# Altium schematic sheet channel-multiplexer.SchDoc
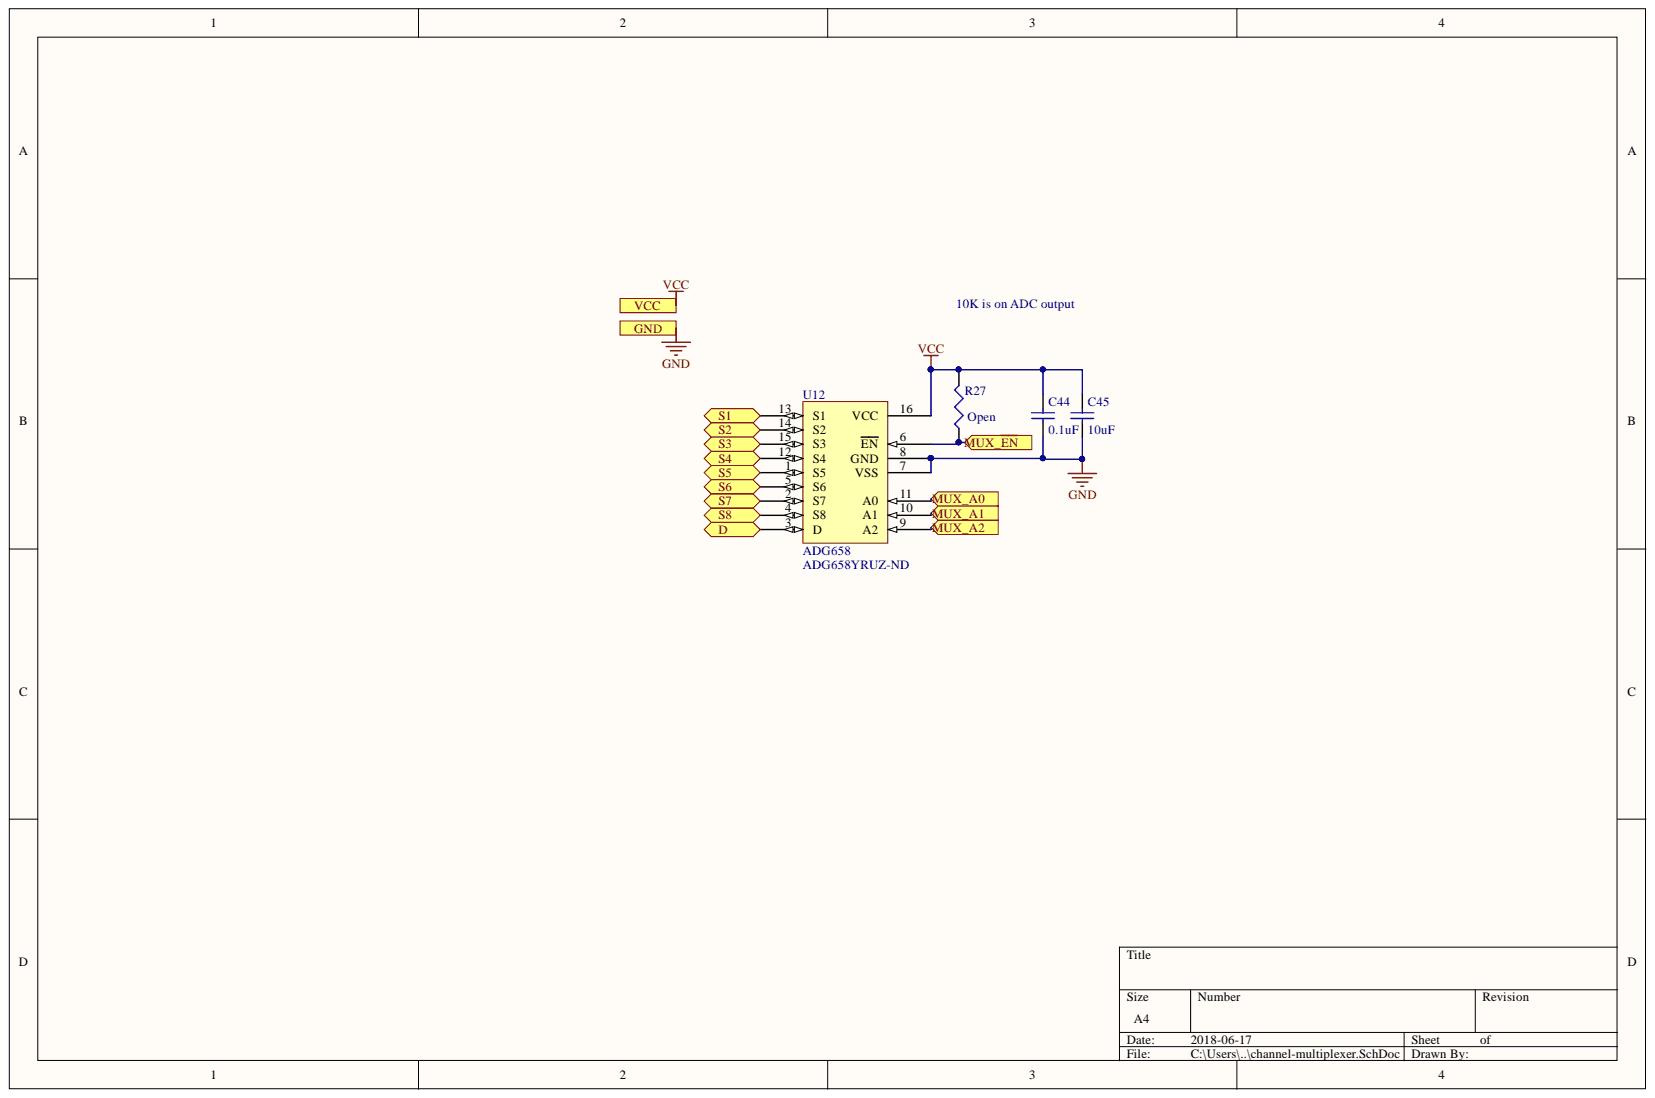
[diagram p0: channel-multiplexer.SchDoc]

## Parts
C44  0.1uF  [0402]
C45  10uF  [1608[0603]]
R27  Open  [0402]
U12  ADG658  Supplier Part Number 1: ADG658YRUZ-ND  [SSOP16_N]

## Ports
D, GND, MUX_A0, MUX_A1, MUX_A2, MUX_~{EN}, S1, S2, S3, S4, S5, S6, S7, S8, VCC

## Nets
D: U12.3(D) ; port D
GND: C44.1 C45.1 U12.7(VSS) U12.8(GND) ; port GND
MUX_A0: U12.11(A0) ; port MUX_A0
MUX_A1: U12.10(A1) ; port MUX_A1
MUX_A2: U12.9(A2) ; port MUX_A2
MUX_~{EN}: R27.1 U12.6(EN) ; port MUX_~{EN}
S1: U12.13(S1) ; port S1
S2: U12.14(S2) ; port S2
S3: U12.15(S3) ; port S3
S4: U12.12(S4) ; port S4
S5: U12.1(S5) ; port S5
S6: U12.5(S6) ; port S6
S7: U12.2(S7) ; port S7
S8: U12.4(S8) ; port S8
VCC: C44.2 C45.2 R27.2 U12.16(VCC) ; port VCC

## Library data of the parts
C44 C45 = Cap Semi: Capacitor (Semiconductor SIM Model); Component Kind: Standard; LatestRevisionDate: 29-May-2009; LatestRevisionNote: IPC-7351 Footprint Added.; Library Name: Miscellaneous Devices.IntLib; PackageDescription: Chip Capacitor; PackageReference: C1206; Published: 8-Jun-2000; Signal Integrity: Cap Semi; Simulation: CAP; Supplier: Digi-Key; Publisher: Altium Limited
  C44: Value: 0.1uF
  C45: Value: 10uF
R27 = Res3: Resistor; Component Kind: Standard; LatestRevisionDate: 29-May-2009; LatestRevisionNote: IPC-7351 Footprint Added.; Library Name: Miscellaneous Devices.IntLib; PackageDescription: Chip Resistor; PackageReference: J1-0603; Published: 8-Jun-2000; Signal Integrity: Res; Simulation: RESISTOR; Supplier: Digi-Key; Value: Open; Publisher: Altium Limited
U12 = ADG658: Eight channel analog multiplexer.; Component Kind: Standard; Supplier: Digi-Key; Supplier 1: Digi-Key; Library Name: PAY.SchLib
